# Altium schematic sheet E-modem-CPU.SchDoc
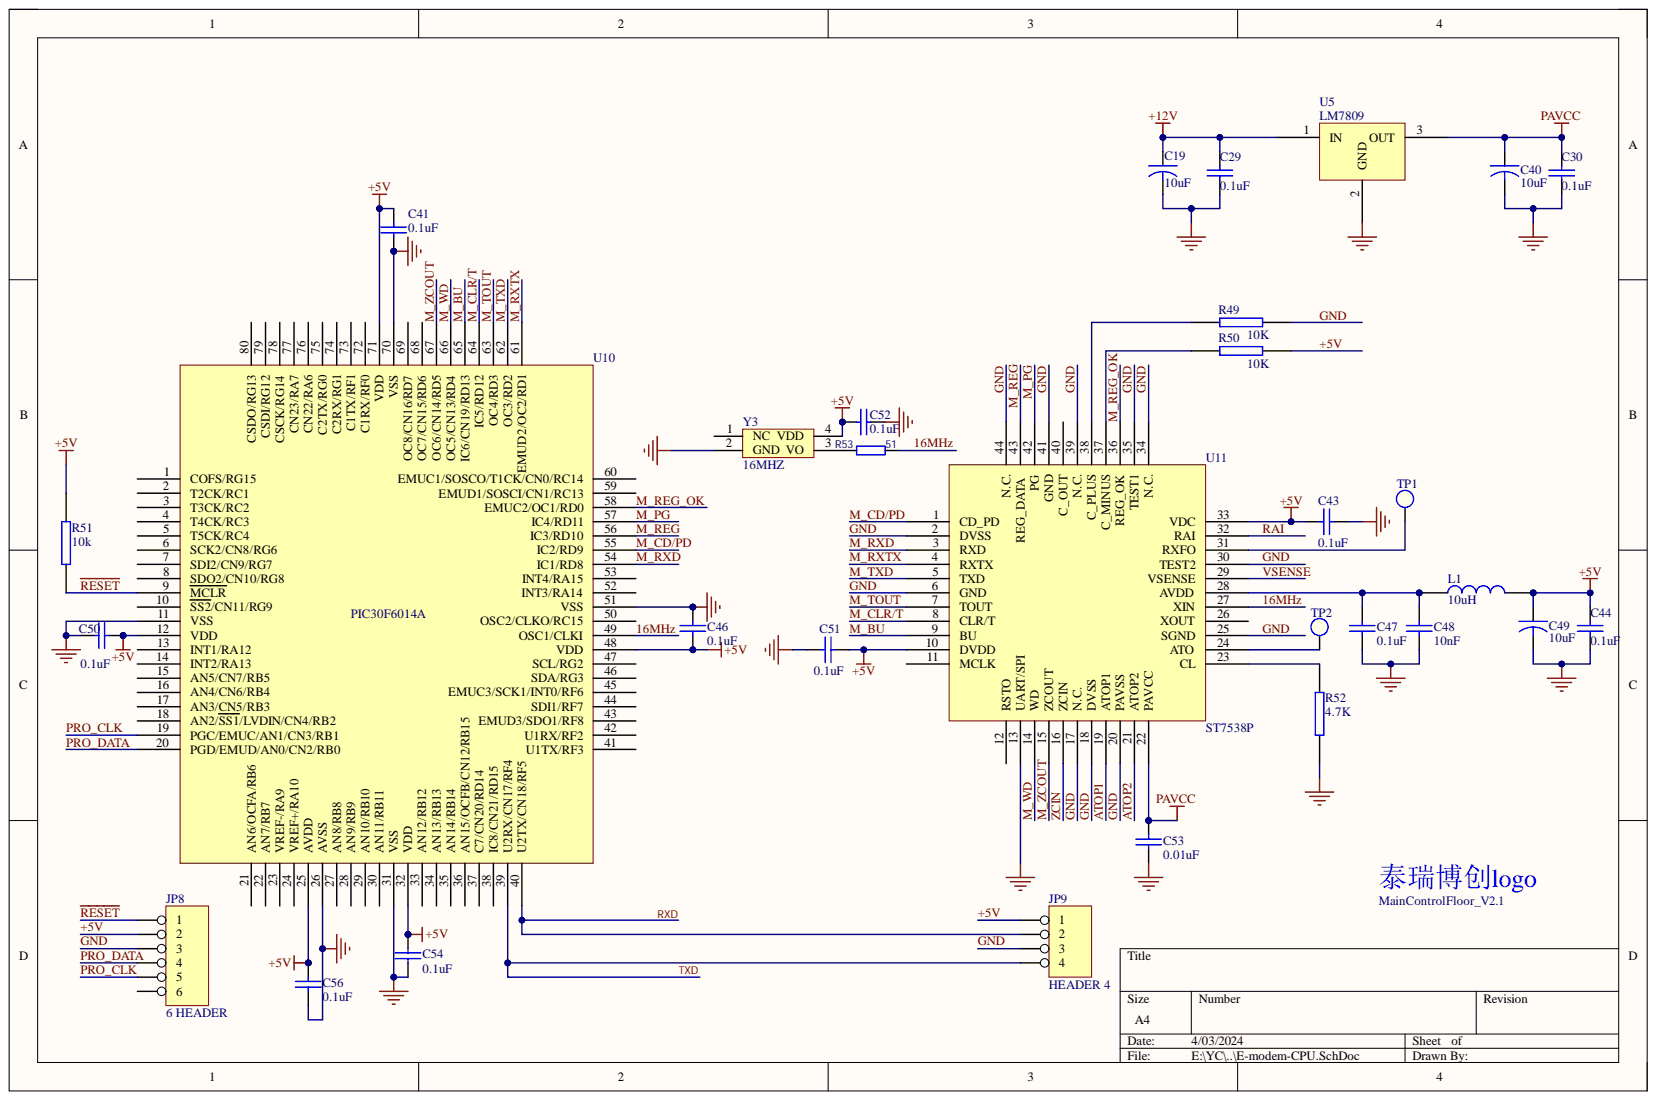
[diagram p0: E-modem-CPU.SchDoc]

## Parts
C19  10uF  [SMD-D1]
C29  0.1uF  [0805C]
C30  0.1uF  [0805C]
C40  10uF  [SMD-D1]
C41  0.1uF  [0805C]
C43  0.1uF  [0805C]
C44  0.1uF  [0805C]
C46  0.1uF  [0805C]
C47  0.1uF  [0805C]
C48  10nF  [0805C]
C49  10uF  [SMD-D1]
C50  0.1uF  [0805C]
C51  0.1uF  [0805C]
C52  0.1uF  [0805C]
C53  0.01uF  [0805C]
C54  0.1uF  [0805C]
C56  0.1uF  [0805C]
JP8  6 HEADER  [SIP6]
JP9  HEADER 4  [SIP4]
L1  10uH  [1212]
MainControlFloor_V2.1  ERSC-HT-MCtrl  [Terrytec LOGO]  (value not drawn)
R49  10K  [0805]
R50  10K  [0805]
R51  10k  [0805]
R52  4.7K  [0805]
R53  51  [0805]
TP1  TERM  [TERM]  (value not drawn)
TP2  TERM  [TERM]  (value not drawn)
U5  LM7809  [TO220]
U10  PIC30F6014A  [TQFP80-PT]  (value not drawn)
U11  ST7538P  [TQFP-44-H]
Y3  16MHZ  [OSC_RECTANGAL]

## Nets
+12V: C19.1 C29.1 U5.1
PAVCC: C30.1 C40.1 C53.1 U5.3 U11.22(PAVCC)
16MHz: R53.1 U10.49(OSC1/CLKI) U11.27(XIN)
+5V: C41.2 C43.1 C44.1 C46.1 C49.1 C50.2 C51.1 C52.1 C54.1 C56.1 JP8.2 JP9.1 L1.2 R50.2 R51.1 U10.12(VDD) U10.25(AVDD) U10.32(VDD) U10.48(VDD) U10.71(VDD) U11.10(DVDD) U11.33(VDC) Y3.4(VDD)
ATOP1: U11.19(ATOP1)
ATOP2: U11.21(ATOP2)
GND: C19.2 C29.2 C30.2 C40.2 C41.1 C43.2 C44.2 C46.2 C47.2 C48.2 C49.2 C50.1 C51.2 C52.2 C53.2 C54.2 C56.2 JP8.3 JP9.3 R49.2 R52.2 U5.2 U10.11(VSS) U10.26(AVSS) U10.31(VSS) U10.51(VSS) U10.70(VSS) U11.2(DVSS) U11.6(GND) U11.13(UART/SPI) U11.17(N.C.) U11.18(DVSS) U11.20(PAVSS) U11.25(SGND) U11.30(TEST2) U11.34(N.C.) U11.35(TEST1) U11.39(N.C.) U11.41(GND) U11.44(N.C.) Y3.2(GND)
M_BU: U10.65(IC6/CN19/RD13) U11.9(BU)
M_CD/PD: U10.55(IC2/RD9) U11.1(CD_PD)
M_CLR/T: U10.64(IC5/RD12) U11.8(CLR/T)
M_PG: U10.57(IC4/RD11) U11.42(PG)
M_REG: U10.56(IC3/RD10) U11.43(REG_DATA)
M_REG_OK: U10.58(EMUC2/OC1/RD0) U11.36(REG_OK)
M_RXD: U10.54(IC1/RD8) U11.3(RXD)
M_RXTX: U10.61(EMUD2/OC2/RD1) U11.4(RXTX)
M_TOUT: U10.63(OC4/RD3) U11.7(TOUT)
M_TXD: U10.62(OC3/RD2) U11.5(TXD)
M_WD: U10.66(OC5/CN13/RD4) U11.14(WD)
M_ZCOUT: U10.67(OC6/CN14/RD5) U11.15(ZCOUT)
PRO_CLK: JP8.5 U10.19(PGC/EMUC/AN1/CN3/RB1)
PRO_DATA: JP8.4 U10.20(PGD/EMUD/AN0/CN2/RB0)
~{RESET}: JP8.1 R51.2 U10.9(MCLR)
RAI: U11.32(RAI)
RXD: JP9.2 U10.40(U2TX/CN18/RF5)
TXD: JP9.4 U10.39(U2RX/CN17/RF4)
VSENSE: U11.29(VSENSE)
ZCIN: U11.16(ZCIN)
NetC47_1: C47.1 C48.1 L1.1 U11.28(AVDD)
NetR49_1: R49.1 U11.38(C_PLUS)
NetR50_1: R50.1 U11.37(C_MINUS)
NetR52_1: R52.1 U11.23(CL)
NetR53_2: R53.2 Y3.3(VO)
NetTP1_1: TP1.1 U11.31(RXFO)
NetTP2_1: TP2.1 U11.24(ATO)

Not connected: JP8 6; U10 1(COFS/RG15) 2(T2CK/RC1) 3(T3CK/RC2) 4(T4CK/RC3) 5(T5CK/RC4) 6(SCK2/CN8/RG6) 7(SDI2/CN9/RG7) 8(SDO2/CN10/RG8) 10(SS2/CN11/RG9) 13(INT1/RA12) 14(INT2/RA13) 15(AN5/CN7/RB5) 16(AN4/CN6/RB4) 17(AN3/CN5/RB3) 18(AN2/SS1/LVDIN/CN4/RB2) 21(AN6/OCFA/RB6) 22(AN7/RB7) 23(VREF-/RA9) 24(VREF+/RA10) 27(AN8/RB8) 28(AN9/RB9) 29(AN10/RB10) 30(AN11/RB11) 33(AN12/RB12) 34(AN13/RB13) 35(AN14/RB14) 36(AN15/OCFB/CN12/RB15) 37(C7/CN20/RD14) 38(IC8/CN21/RD15) 41(U1TX/RF3) 42(U1RX/RF2) 43(EMUD3/SDO1/RF8) 44(SDI1/RF7) 45(EMUC3/SCK1/INT0/RF6) 46(SDA/RG3) 47(SCL/RG2) 50(OSC2/CLKO/RC15) 52(INT3/RA14) 53(INT4/RA15) 59(EMUD1/SOSCI/CN1/RC13) 60(EMUC1/SOSCO/T1CK/CN0/RC14) 68(OC7/CN15/RD6) 69(OC8/CN16/RD7) 72(C1RX/RF0) 73(C1TX/RF1) 74(C2RX/RG1) 75(C2TX/RG0) 76(CN22/RA6) 77(CN23/RA7) 78(CSCK/RG14) 79(CSDI/RG12) 80(CSDO/RG13); U11 11(MCLK) 12(RSTO) 26(XOUT) 40(C_OUT); Y3 1(NC)

## Library data of the parts
C19 C40 C49 = CAPACITOR: Component Kind: Standard; Description: Capacitor
C29 C30 C41 C43 C44 C46-C48 C50-C54 C56 = CAP: Capacitor; Component Kind: Standard
JP9 = 4 HEADER: 4 Pin Header; Component Kind: Standard
L1 = INDUCTOR: Component Kind: Standard
MainControlFloor_V2.1 = Terrytec LOGO: Component Kind: Standard; Library Name: TR_SCHLIB.SchLib
R49-R52 = RES2: Component Kind: Standard
R53 = RES: Component Kind: Standard; Library Name: sixarms--BGA.SCHLIB
U5 = LM7805: Component Kind: Standard
U11 = ST7538Q: U?; Component Kind: Standard
Y3 = OSC: Component Kind: Standard; Library Name: Previous Backup of Schlib1.Lib
JP8 = 6 HEADER: Component Kind: Standard
TP1 TP2 = TERM: Component Kind: Standard
U10 = PIC30F6014A: Component Kind: Standard
